# Altium schematic sheet 02_Killswitch.SchDoc(02_Killswitch)
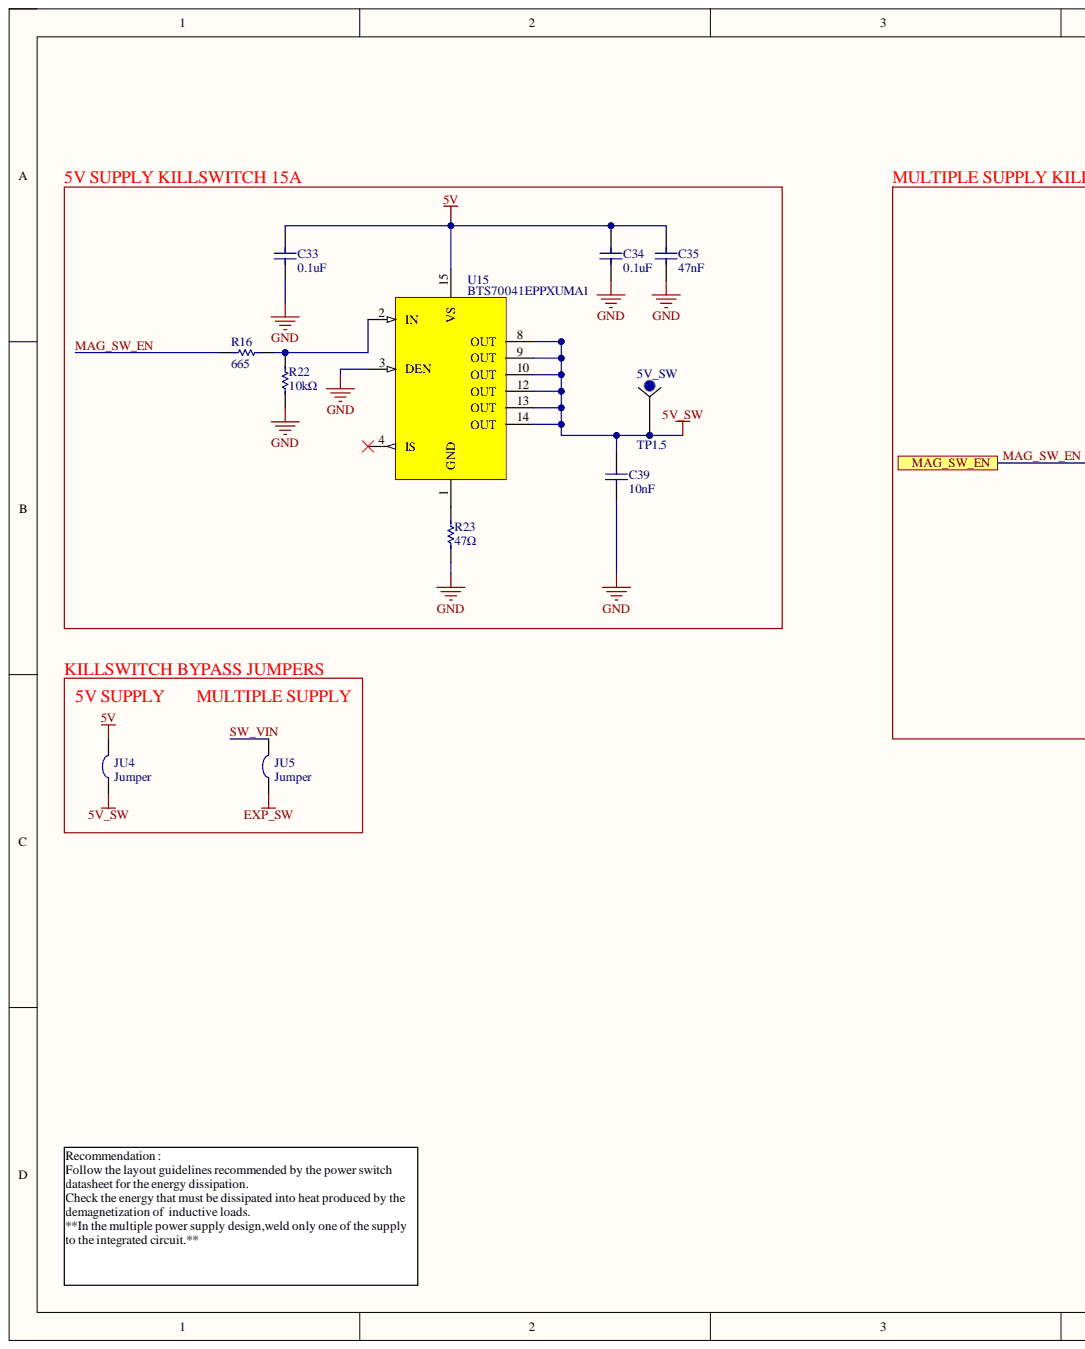
[diagram p0: 02_Killswitch.SchDoc, left]
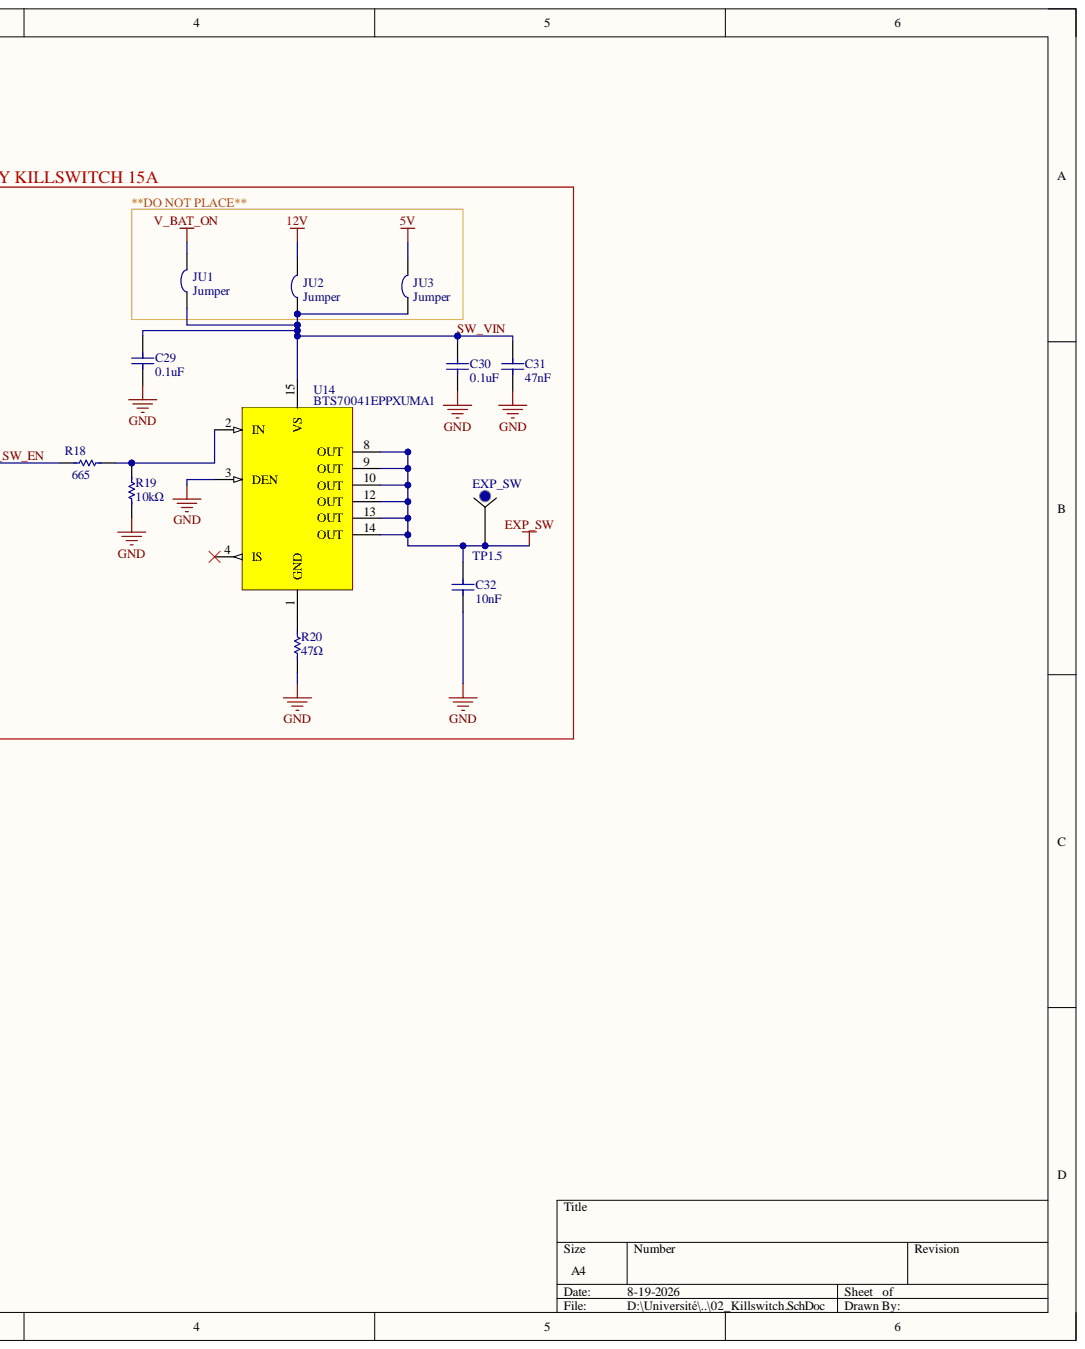
[diagram p1: 02_Killswitch.SchDoc, right]

## Parts
5V_SW  TP1.5  [TP1.5]  (no BOM)
C29  0.1uF  [CAPC200X125X145L50N]  (no BOM)
C30  0.1uF  [CAPC200X125X95L50N]  (no BOM)
C31  47nF  [CAPC200X125X95L50N]  (no BOM)
C32  10nF  [CAPC200X125X95L50N]  (no BOM)
C33  0.1uF  [CAPC200X125X145L50N]  (no BOM)
C34  0.1uF  [CAPC200X125X95L50N]  (no BOM)
C35  47nF  [CAPC200X125X95L50N]  (no BOM)
C39  10nF  [CAPC200X125X95L50N]  (no BOM)
EXP_SW  TP1.5  [TP1.5]  (no BOM)
JU1  Jumper  [JUMPP320X160X180L80X120N]  (no BOM)
JU2  Jumper  [JUMPP320X160X180L80X120N]  (no BOM)
JU3  Jumper  [JUMPP320X160X180L80X120N]  (no BOM)
JU4  Jumper  [JUMPP320X160X180L80X120N]  (no BOM)
JU5  Jumper  [JUMPP320X160X180L80X120N]  (no BOM)
R16  665  [RESC310X160X65L55N]  (no BOM)
R18  665  [RESC310X160X65L55N]  (no BOM)
R19  10kΩ  [RESC200X125X60L35N]  (no BOM)
R20  47Ω  [RESC200X125X60L35N]  (no BOM)
R22  10kΩ  [RESC200X125X60L35N]  (no BOM)
R23  47Ω  [RESC200X125X60L35N]  (no BOM)
U14  BTS70041EPPXUMA1  [TSSOP-14_400x500x115]  (no BOM)
U15  BTS70041EPPXUMA1  [TSSOP-14_400x500x115]  (no BOM)

## Ports
MAG_SW_EN

## Nets
5V: C33.2 C34.2 C35.2 JU3.2 JU4.2 U15.15(VS)
5V_SW: 5V_SW.1 C39.2 JU4.1 U15.8(OUT) U15.9(OUT) U15.10(OUT) U15.12(OUT) U15.13(OUT) U15.14(OUT)
12V: JU2.2
EXP_SW: C32.2 EXP_SW.1 JU5.1 U14.8(OUT) U14.9(OUT) U14.10(OUT) U14.12(OUT) U14.13(OUT) U14.14(OUT)
GND: C29.1 C30.1 C31.1 C32.1 C33.1 C34.1 C35.1 C39.1 R19.1 R20.1 R22.1 R23.1 U14.3(DEN) U15.3(DEN)
V_BAT_ON: JU1.2
MAG_SW_EN: R16.1 R18.1 ; port MAG_SW_EN
SW_VIN: C29.2 C30.2 C31.2 JU1.1 JU2.1 JU3.1 JU5.2 U14.15(VS)
NetR16_2: R16.2 R22.2 U15.2(IN)
NetR18_2: R18.2 R19.2 U14.2(IN)
NetR20_2: R20.2 U14.1(GND)
NetR23_2: R23.2 U15.1(GND)

Not connected: U14 4(IS); U15 4(IS)

## Library data of the parts
5V_SW EXP_SW = TP1.5: Testpoint 1.5mm; Library Name: PCB_Power.SchLib
C29 C33 = YAGEO_CC0805KRX7R9BB104: CAP CER 0.1UF 50V X7R 0805; Manufacturer: Yageo; Detailed description: ±10% 50V Ceramic Capacitor X7R 0805 (2012 Metric); Operating description: -55°C ~ 125°C; ComponentLink1Description: Datasheet; ComponentLink1URL: https://www.yageo.com/upload/media/product/productsearch/datasheet/mlcc/UPY-GPHC_X7R_6.3V-to-50V_18.pdf; LCSC_Part_Number: C49678; Library Name: PCB_Power.SchLib; Manufacturer part number: CC0805KRX7R9BB104; Price: 0.16; Supplier: Digikey; Supplier part number: 311-1140-1-ND; Value: 0.1uF
C30 C34 = YAGEO_CC0805KRX7R7BB104: CAP CER 0.1UF 16V X7R 0805; Manufacturer: Yageo; Detailed description: ±10% 16V Ceramic Capacitor X7R 0805 (2012 Metric); ComponentLink1Description: Datasheet; ComponentLink1URL: https://www.yageo.com/upload/media/product/productsearch/datasheet/mlcc/UPY-GPHC_X7R_6.3V-to-50V_18.pdf; LCSC_Part_Number: C519980; Library Name: PCB_Power.SchLib; Manufacturer part number: CC0805KRX7R7BB104; Operating temperature: -55°C ~ 125°C; Price: 0.17; Supplier: Digikey; Supplier part number: 311-1142-1-ND; Value: 0.1uF
C31 C35 = YAGEO_CC0805KRX7R9BB473: CAP CER 0.047UF 50V X7R 0805; Manufacturer: Yageo; Detailed description: 0.047µF ±10% 50V Ceramic Capacitor X7R 0805 (2012 Metric); Operating description: -55°C ~ 125°C; ComponentLink1Description: Datasheet; ComponentLink1URL: https://www.yageo.com/upload/media/product/productsearch/datasheet/mlcc/UPY-GPHC_X7R_6.3V-to-50V_18.pdf; LCSC_Part_Number: C107154; Library Name: PCB_Power.SchLib; Manufacturer part number: CC0805KRX7R9BB473; Price: 0.17; Supplier: Digikey; Supplier part number: 311-1431-1-ND; Value: 47nF
C32 C39 = YAGEO_CC0805MRX7R9BB103: CAP CER 10000PF 50V X7R 0805; Manufacturer: Yageo; Detailed description: 10000pF ±20% 50V Ceramic Capacitor X7R 0805 (2012 Metric); Operating description: -55°C ~ 125°C; ComponentLink1Description: Datasheet; ComponentLink1URL: https://www.yageo.com/upload/media/product/productsearch/datasheet/mlcc/UPY-GPHC_X7R_6.3V-to-50V_18.pdf; LCSC_Part_Number: C2169410; Library Name: PCB_Power.SchLib; Manufacturer part number: CC0805MRX7R9BB103; Price: 0.14; Supplier: Digikey; Supplier part number: 311-4350-1-ND; Value: 10nF
JU1-JU5 = Jumper: PUT SOME SOLDER ON MEEE; Library Name: PCB_Power.SchLib
R16 R18 = YAGEO_RC1206FR-07665RL: RES SMD 665 OHM 1% 1/4W 1206; Manufacturer: Yageo; Detailed description: 665 ±1% 0.25W, 1/4W Chip Resistor 1206 (3216 Metric) - Thick Film; ComponentLink1Description: Datasheet; ComponentLink1URL: https://www.yageo.com/upload/media/product/productsearch/datasheet/rchip/PYu-RC_Group_51_RoHS_L_11.pdf; Library Name: PCB_POWER.IntLib; Manufacturer part number: RC1206FR-07665RL; Operating temperature: -55°C ~ 155°C; Price: 0.15; Supplier: Digikey; Supplier part number: 311-665FRCT-ND; Value: 665
R19 R22 = YAGEO_RC0805JR-0710KL: RES SMD 10K OHM 5% 1/8W 0805; Manufacturer: Yageo; Detailed description: 10 ±5% 0.125W, 1/8W Chip Resistor 0805 (2012 Metric) - Thick Film; ComponentLink1Description: Datasheet; ComponentLink1URL: https://www.yageo.com/upload/media/product/productsearch/datasheet/rchip/PYu-RC_Group_51_RoHS_L_11.pdf; LCSC_Part_Number: C100047; Library Name: PCB_Power.SchLib; Manufacturer part number: RC0805JR-0710KL; Operating temperature: -55°C ~ 155°C; Price: 0.15; Supplier: Digikey; Supplier part number: 311-10KARCT-ND; Value: 10kΩ
R20 R23 = YAGEO_RC0805FR-0747RL: RES SMD 47 OHM 1% 1/8W 0805; Manufacturer: Yageo; Detailed description: 47 Ohms ±1% 0.125W, 1/8W Chip Resistor 0805 (2012 Metric)  Thick Film; Operating description: -55°C ~ 155°C; ComponentLink1Description: Datasheet; ComponentLink1URL: https://www.yageo.com/upload/media/product/productsearch/datasheet/rchip/PYu-RC_Group_51_RoHS_L_11.pdf; LCSC_Part_Number: C114541; Library Name: PCB_Power.SchLib; Manufacturer part number: RC0805FR-0747RL; Price: 0.15; Supplier: Digikey; Supplier part number: 311-47.0CRCT-ND; Value: 47Ω
U14 U15 = IT_BTS70041EPPXUMA1: IC PWR SWTCH N-CHAN 1:1 TSDSO-14; Manufacturer: Infineon Technologies; Detailed description: Power Switch/Driver 1:1 N-Channel 15A PG-TSDSO-14-22; ComponentLink1Description: Datasheet; ComponentLink1URL: https://www.infineon.com/dgdl/Infineon-BTS7004-1EPP-DS-v01_00-EN.pdf?fileId=5546d4626102d35a016147550a725555; LCSC_Part_Number: C5311594; Library Name: PCB_Power.SchLib; Manufacturer Part Number: BTS70041EPPXUMA1; Operating temperature: -40°C ~ 150°C (TJ); Price: 4.06; Supplier: Digikey; Supplier part number: BTS70041EPPXUMA1CT-ND
